# Altium schematic sheet lis2dh_spi.SchDoc
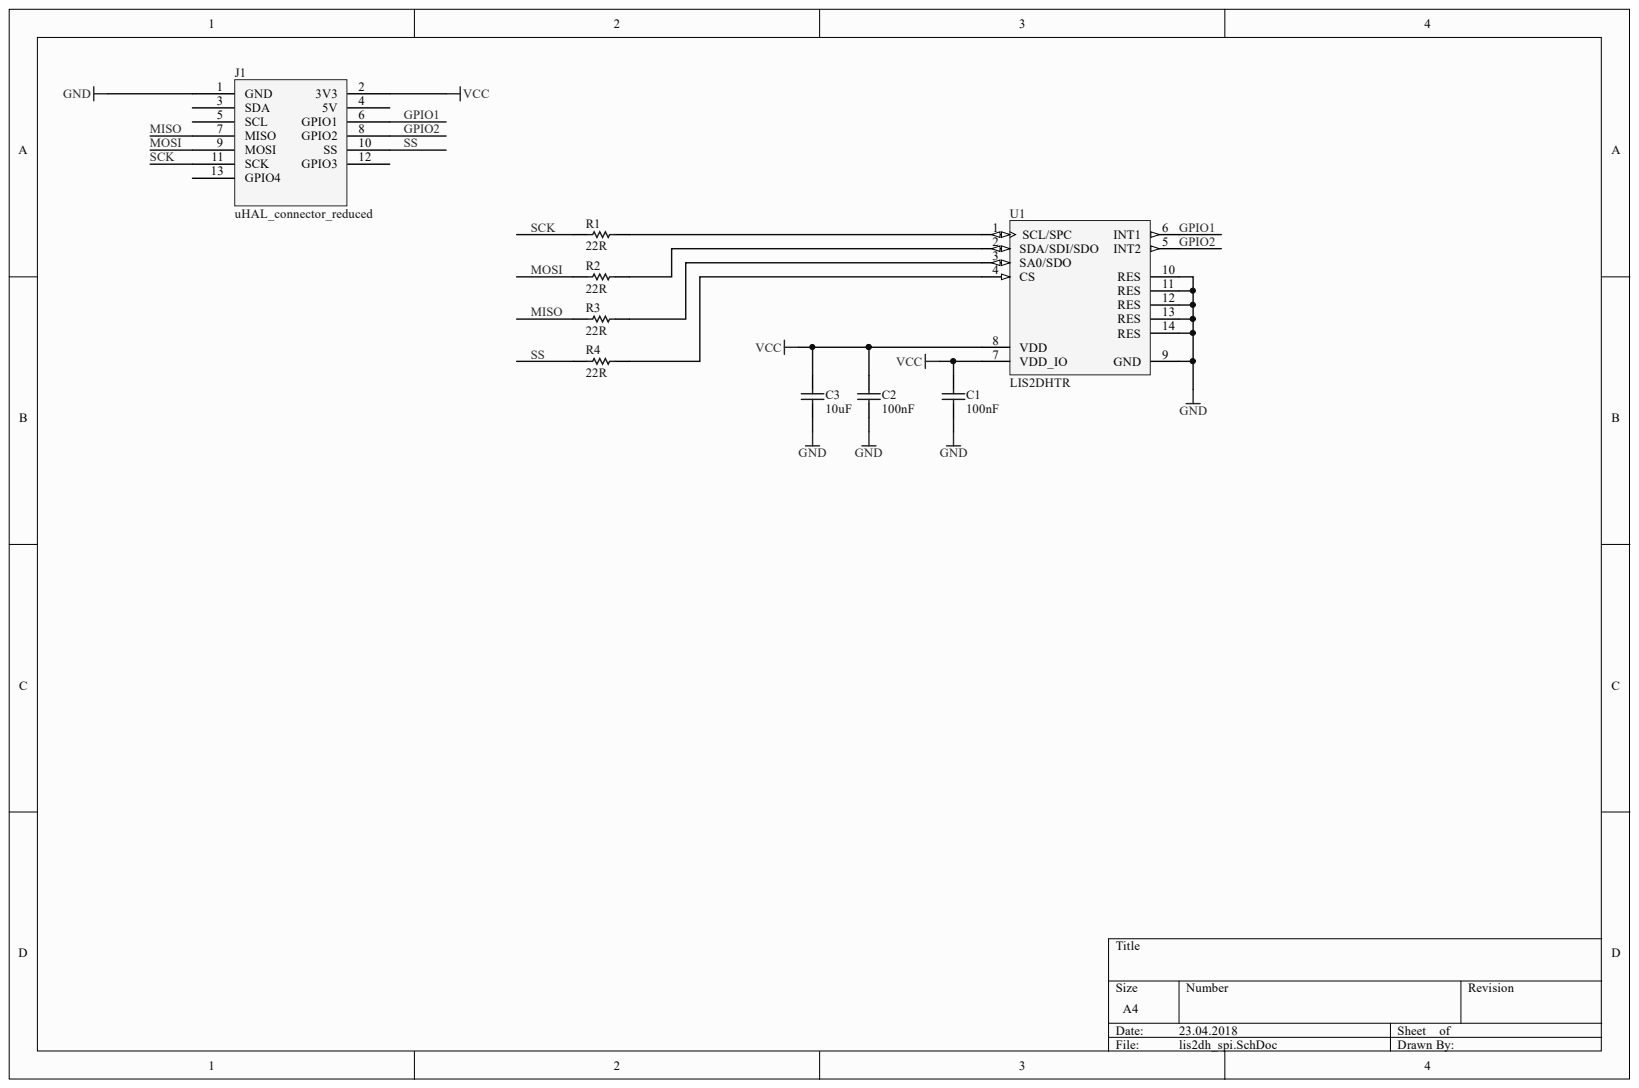
[diagram p0: lis2dh_spi.SchDoc]

## Parts
C1  Capacitance: 100nF  [CAPC1608X90X35ML10T15]  (hidden comment: Murata GCM188R71H104KA57D)
C2  Capacitance: 100nF  [CAPC1608X90X35ML10T15]  (hidden comment: Murata GCM188R71H104KA57D)
C3  Capacitance: 10uF  [CAPC1608X90X35ML10T15]  (hidden comment: Murata GCM188R71H104KA57D)
J1  uHAL_connector_reduced  [PCB-45ncoppm4oopozvqifsq-1]
R1  22R  [RESC1608X05N]
R2  22R  [RESC1608X05N]
R3  22R  [RESC1608X05N]
R4  22R  [RESC1608X05N]
U1  LIS2DHTR  [LGA-14_N]

## Nets
GND: C1.2 C2.2 C3.2 J1.1(GND) U1.9(GND) U1.10(RES) U1.11(RES) U1.12(RES) U1.13(RES) U1.14(RES)
VCC: C1.1 C2.1 C3.1 J1.2(3V3) U1.7(VDD_IO) U1.8(VDD)
GPIO1: J1.6(GPIO1) U1.6(INT1)
GPIO2: J1.8(GPIO2) U1.5(INT2)
MISO: J1.7(MISO) R3.2
MOSI: J1.9(MOSI) R2.2
SCK: J1.11(SCK) R1.2
SS: J1.10(SS) R4.2
NetR1_1: R1.1 U1.1(SCL/SPC)
NetR2_1: R2.1 U1.2(SDA/SDI/SDO)
NetR3_1: R3.1 U1.3(SA0/SDO)
NetR4_1: R4.1 U1.4(CS)

Not connected: J1 3(SDA) 4(5V) 5(SCL) 12(GPIO3) 13(GPIO4)

## Library data of the parts
C1-C3 = CMP-119667-3: CAP CER 0.1UF 50V 10% X7R 0603; Lead-Free Status: Lead Free; Voltage Rating (DC): 50.0 V; Capacitance Tolerance: ±10%; Component Kind: Standard; Dielectric Characteristic: X7R; Library Name: CommunityVault; OctopartID: 3480fdf266eeeb47; Octopart Url: https://octopart.com/gcm188r71h104ka57d-murata-7894792; Packaging: Cut Tape (CT); REACH SVHC Compliance: No SVHC; RoHS: Compliant
J1 = CMP-2q23h2cwhjlfm8s6r7i8-1: connector for uHAL with reduced pin number; Component Kind: Standard; CustomComponentID: 2q23h2cwhjlfm8s6r7i8; Library Name: CommunityVault
R1-R4 = CMP-31acf99032f6ee6b-1: RCA Series 0603 0.1 W 0 O Jumper Surface Mount Thick Film Chip Resistor; Manufacturer Part Number: RCA06030000ZSEA; Case/Package (SI): 1608; Lead-Free Status: Lead Free; Power Rating: 100 mW; Case/Package: 0603, 1608; Component Kind: Standard; Library Name: CommunityVault; OctopartID: 31acf99032f6ee6b; Octopart URL: https://octopart.com/rca06030000zsea-vishay-20325948; Packaging: Cut Tape (CT); RoHS: Compliant
U1 = CMP-4868188-4: MEMS Digital Output Motion Sensor Ultra Low-Power High Performance 3-Axes "femto" Accelerometer, 1.71 to 3.6 V, -40 to 85 degC, 14-pin (LGA-14), Pb-Free, Tape and Reel; Lead-Free Status: Lead Free; Component Kind: Standard; Library Name: CommunityVault; Lifecycle Status: Active; Number of Pins: 14; OctopartID: 4a04825e76c295c3; Octopart Url: https://octopart.com/lis2dhtr-stmicroelectronics-22026656; Packaging: Cut Tape (CT); REACH SVHC Compliance: No SVHC; RoHS: Compliant
